# Altium schematic sheet CH340_USB_To_UART.SchDoc
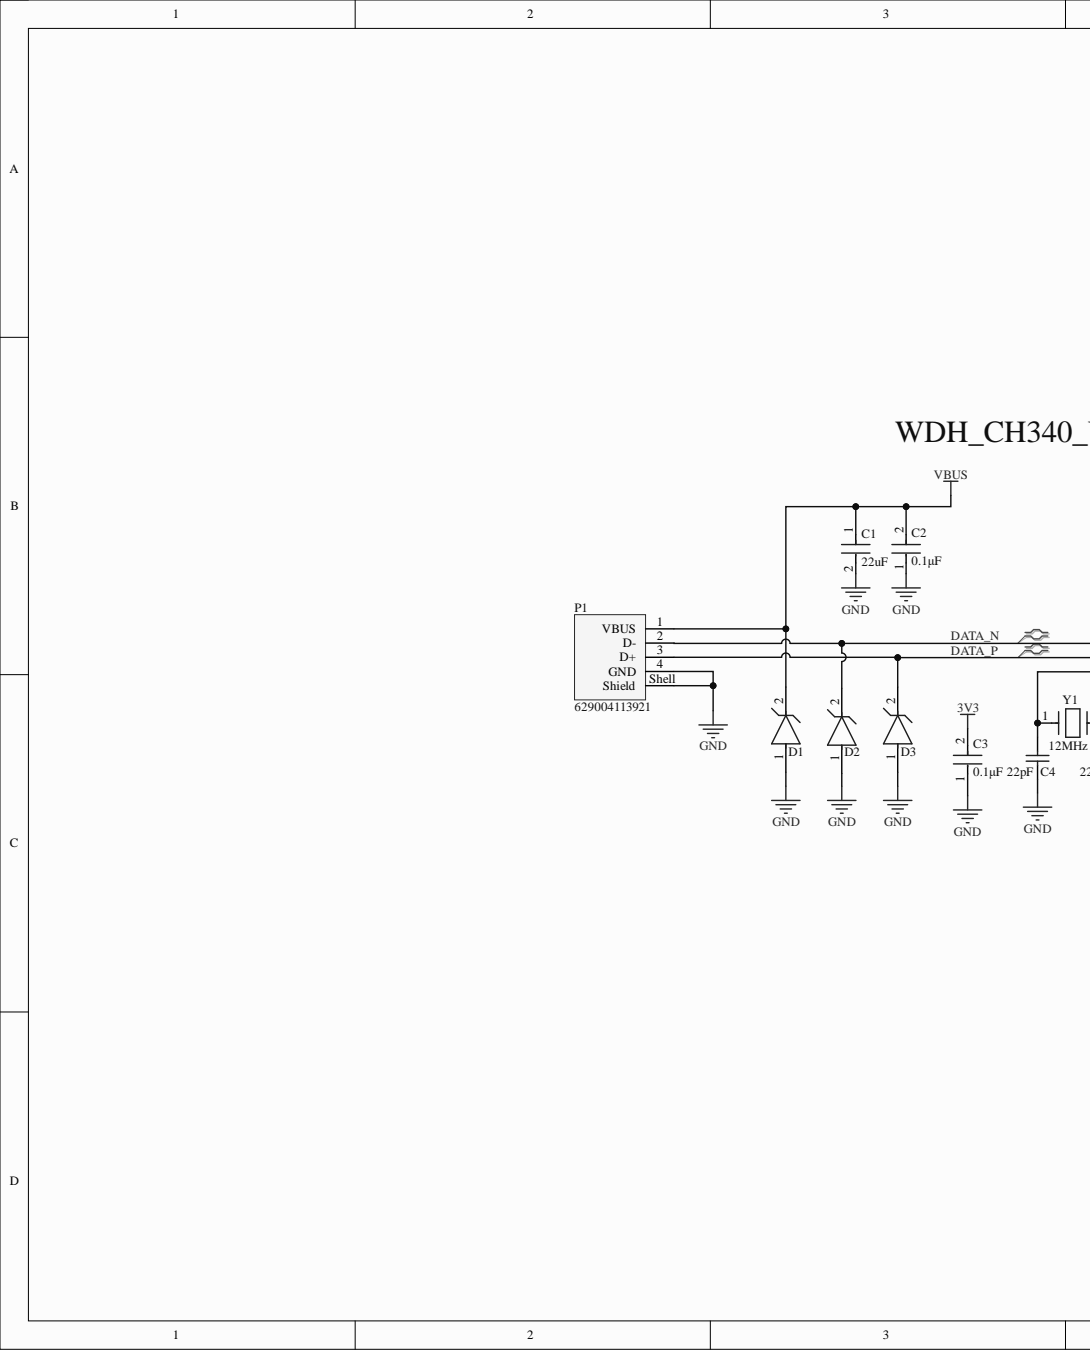
[diagram p0: CH340_USB_To_UART.SchDoc, left]
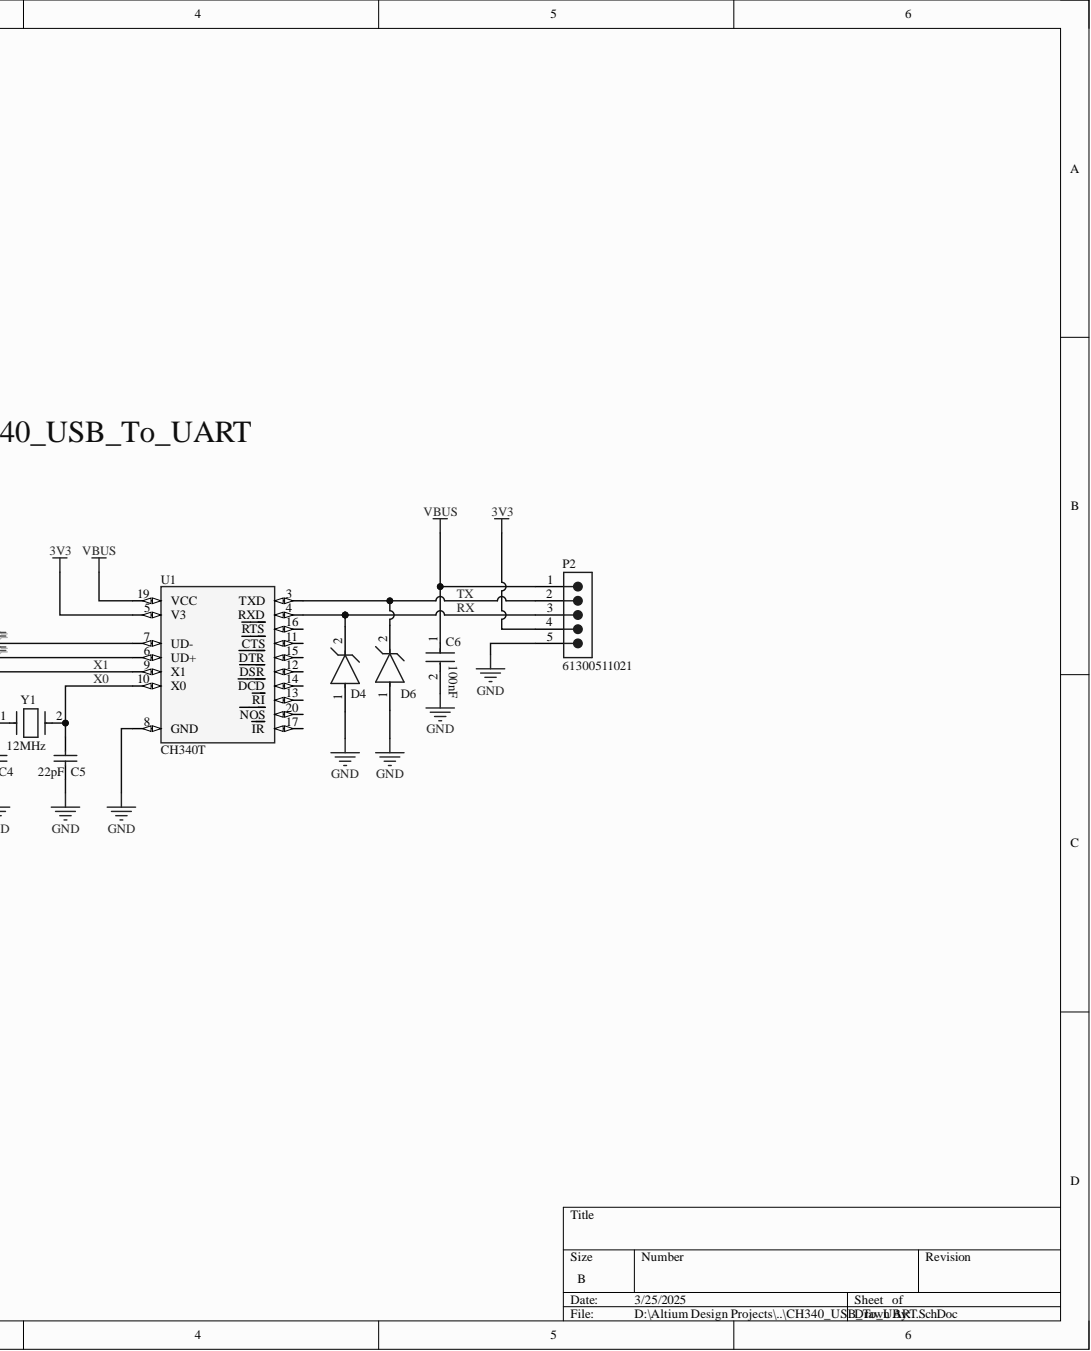
[diagram p1: CH340_USB_To_UART.SchDoc, right]

## Parts
C1  value 22uF  Capacitance: 22uF  [FP-CL05A226MQ5N6J8-IPC_C]  (hidden comment: CL05A226MQ5N6J8)
C2  value 0.1μF  Capacitance: 0.1μF  [FP-GRM15-MFG]  (hidden comment: GRM155R71C104KA88D)
C3  value 0.1μF  Capacitance: 0.1μF  [FP-GRM15-MFG]  (hidden comment: GRM155R71C104KA88D)
C4  Capactance: 22pF  [CAPC1006X50X20ML10T10]  (hidden comment: 0402ZK220GBSTR..)
C5  Capactance: 22pF  [CAPC1006X50X20ML10T10]  (hidden comment: 0402ZK220GBSTR..)
C6  Capacitance: 100nF  [FP-C1005-050-0_05-MFG]  (hidden comment: C1005X7R1C104K050BC)
D1  UCLAMP3601P.TNT  [FP-SLP1006P2-MFG]  (value not drawn)
D2  UCLAMP3601P.TNT  [FP-SLP1006P2-MFG]  (value not drawn)
D3  UCLAMP3601P.TNT  [FP-SLP1006P2-MFG]  (value not drawn)
D4  UCLAMP3601P.TNT  [FP-SLP1006P2-MFG]  (value not drawn)
D6  UCLAMP3601P.TNT  [FP-SLP1006P2-MFG]  (value not drawn)
P1  629004113921  [629004113921]
P2  61300511021  [61300511021]
U1  CH340T  [SSOP20W]
Y1  Frequency: 12MHz  [FP-ABM3-MFG]  (hidden comment: ABM3-12.000MHZ-D2Y-T)

## Nets
3V3: C3.2 P2.4 U1.5(V3)
GND: C1.2 C2.1 C3.1 C4.1 C5.1 C6.2 D1.1 D2.1 D3.1 D4.1 D6.1 P1.4(GND) P1.Shell(Shield) P2.5 U1.8(GND)
VBUS: C1.1 C2.2 C6.1 D1.2 P1.1(VBUS) P2.1 U1.19(VCC)
DATA_P: D3.2 P1.3(D+) U1.6(UD+)
RX: D4.2 P2.3 U1.4(RXD)
TX: D6.2 P2.2 U1.3(TXD)
X0: C5.2 U1.10(X0) Y1.2
X1: C4.2 U1.9(X1) Y1.1
NetD2_2: D2.2 P1.2(D-) U1.7(UD-)
DATA_N:

Not connected: U1 11(CTS) 12(DSR) 13(RI) 14(DCD) 15(DTR) 16(RTS) 17(IR) 20(NOS)

## Library data of the parts
C1 = CMP-13271-000001-1: CAP CER 22UF 6.3V X5R 0402; Manufacturer: Samsung Electro-Mechanics; Material: Ceramic; Temperature Coefficient: 15%; Tolerance: 20%; Automotive: No; Category: Cap; Component Kind: Standard; ComponentLink2Description: Datasheet; ComponentLink2URL: http://www.samsungsem.com/kr/support/library/product-catalog/__icsFiles/afieldfile/2018/11/28/MLCC.pdf; Device Class L1: Passive Components; Device Class L2: Capacitors; Device Class L3: Ceramic Capacitors; Digikey Description: CAP CER 22UF 6.3V X5R 0402; Height: 0.55mm; Ipc Land Pattern Name: CAPC10050X50; Lead Free: Yes; Library Name: Altium Content Vault; Manufacturer Part Number: CL05A226MQ5N6J8; Octopart: https://octopart.com/cl05a226mq5n6j8-samsung-82337113; Package: 0402; RoHS: TRUE; Temperature: -55°C to +85°C; Temperature Characteristic: X5R; Temperature Range High: +85°C; Temperature Range Low: -55°C; Voltage Rating: 6.3V
C2 C3 = CMP-2100-03641-2: CAP CER 0.1UF 16V X7R 0402; Manufacturer: Murata; Material: Ceramic; Standoff Height: 0mm; Tolerance: 10%; Voltage Rating: 16Vdc; Category: Cap; Component Kind: Standard; ComponentLink2Description: Datasheet; ComponentLink2URL: https://search.murata.co.jp/Ceramy/image/img/A01X/G101/ENG/GRM155R71H471KA01-01A.pdf; Device Class L1: Passive Components; Device Class L2: Capacitors; Device Class L3: Ceramic Capacitors; Digikey Description: CAP CER 0.1UF 16V X7R 0402; Height: 0.55mm; Ipc Land Pattern Name: CAPC10050X219; Lead Free: yes; Library Name: Altium Content Vault; Manufacturer Part Number: GRM155R71C104KA88D; Mouser Description: 0402 0.1 uF 16V ±10 % Tolerance X7R SMT Multilayer Ceramic Capacitor; Octopart: https://octopart.com/grm155r71c104ka88d-murata-196880; Package: GRM15; RoHS: TRUE; Temperature: -55°C to +125°C; Temperature Characteristic: X7R; Temperature Range High: +125°C; Temperature Range Low: -55°C
C4 C5 = CMP-2008-04844-1: Manufacturer: AVX Interconnect / Elco; Component Kind: Standard; Library Name: Altium Content Vault; Part Number: 0402ZK220GBSTR..
C6 = CMP-08246-004182-1: Multilayer Ceramic Capacitors 100nF ±10% 16V X7R SMD 0402; Case Code (Imperial): 0402; Case Code (Metric): 1005; Case/Package: 0402; Component Kind: Standard; Construction: Flat; Depth: 0.5mm; Dielectric: X7R; Dielectric Material: Ceramic; Height: 0.5mm; Lead Free: Lead Free; Length: 1mm; Library Name: Altium Content Vault; Max Operating Temperature: 125°C; Min Operating Temperature: -55°C; Mount: Surface Mount; Number of Pins: 2; Package Quantity: 1; Packaging: Tape and Reel; Radiation Hardening: No; Resistor Type: Ceramic; RoHS Compliant: Yes; Series: C; Termination: SMD/SMT; Thickness: 0.022inch; Tolerance: 10%; Voltage: 16V; Voltage Rating: 16V; Voltage Rating (DC): 16V; Weight: 2.3E-05oz; Width: 0.02inch
D1-D4 D6 = CMP-56445-000005-1: TVS DIODE 33VWM 60VC SLP1006P2; Clamping Voltage: 60V; Diode Type: ZENER; Direction: Unidirectional; Element Configuration: Single; Leakage Current: 1uA; Max Breakdown Voltage: 45V; Min Breakdown Voltage: 36V; Number of Unidirectional Channels: 1; Peak Pulse Current: 2A; Peak Pulse Power: 125W; Power Dissipation: 170W; Power Line Protection: No; Reverse Standoff Voltage: 33V; Case Code (Imperial): 0402; Case Code (Metric): 1006; Case/Package: SMD/SMT; Clamping Voltage-Max: 60V; Component Kind: Standard; Depth: 0.6mm; Lead Free: Lead Free; Length: 1mm; Library Name: Altium Content Vault; Max Operating Temperature: 125°C; Min Operating Temperature: -40°C; Mount: Surface Mount; Number of Pins: 2; Packaging: Tape and Reel; Radiation Hardening: No; Resistor Type: Zener; RoHS Compliant: Yes; Series: Automotive, AEC-Q100, uClamp®; Working Voltage: 33V
P1 = CMP-1502-03242-2: NexarPartId: 19991169; Connector Type: USB; Contact Resistance: 20mΩ; Current Rating: 1.5A; Gender: Male; Insulation Resistance: 1GΩ; Number of Contacts: 4; Operating Supply Voltage: 30V; USB Standard: USB 2.0; Component Kind: Standard; Contact Plating: Gold; Features: Shielded; Lead Free: Lead Free; Length: 18.8mm; Library Name: Altium Content Vault; Max Operating Temperature: 105°C; Min Operating Temperature: -40°C; Mount: Horizontal, Surface Mount; Number of Pins: 4; Orientation: Horizontal; REACH SVHC: Unknown; RoHS: Compliant; Termination: SMD/SMT
P2 = CMP-1502-01067-3: NexarPartId: 32855525; Connector Type: Male Pin; Contact Resistance: 20mΩ; Current Rating: 3A; Gender: Male; Number of Contacts: 5; Operating Supply Voltage: 250V; Pitch: 2.54mm; Color: Black; Component Kind: Standard; Contact Material: Copper; Contact Mating Length: 5.9944mm; Contact Plating: Gold; Lead Pitch: 2.54mm; Library Name: Altium Content Vault; Mount: Through Hole; Number of Pins: 5; Number of Positions: 5; Number of Rows: 1; Packaging: Bulk; REACH SVHC: Unknown; RoHS: Compliant; Termination: Solder
U1 = CH340T: Interface Development Tools USB - Serial Convert with CH340T; MF: wch; Check_prices: https://www.snapeda.com/parts/CH340T/WCH/view-part/?ref=eda; Component Kind: Standard; Library Name: CH340T.IntLib; MP: CH340T; Package: None; SnapEDA_Link: https://www.snapeda.com/parts/CH340T/WCH/view-part/?ref=snap
Y1 = CMP-27762-007917-1: Crystal 12MHz ±20ppm 18pF SMD-2 5mm x 3.2mm; ESR (Equivalent Series Resistance): 60R; Frequency Stability: 30ppm; Case/Package: CSMD; Component Kind: Standard; Depth: 3.2mm; Frequency Tolerance: 20ppm; Height: 0.051inch; Lead Free: Lead Free; Length: 5mm; Library Name: Altium Content Vault; Load Capacitance: 18pF; Max Operating Temperature: 85°C; Min Operating Temperature: -40°C; Mount: Surface Mount; Number of Pins: 2; Operating Frequency: 12 MHz; Package Quantity: 1000; Packaging: Tape and Reel; Parameter 1: 12MHz; Radiation Hardening: No; Resistor Type: Ceramic; Series: ABM3; Series Resistance: 60R; Termination: SMD/SMT; Tolerance: 20ppm; Width: 0.126inch
